# Altium schematic sheet MainBoard_Peripheral.SchDoc
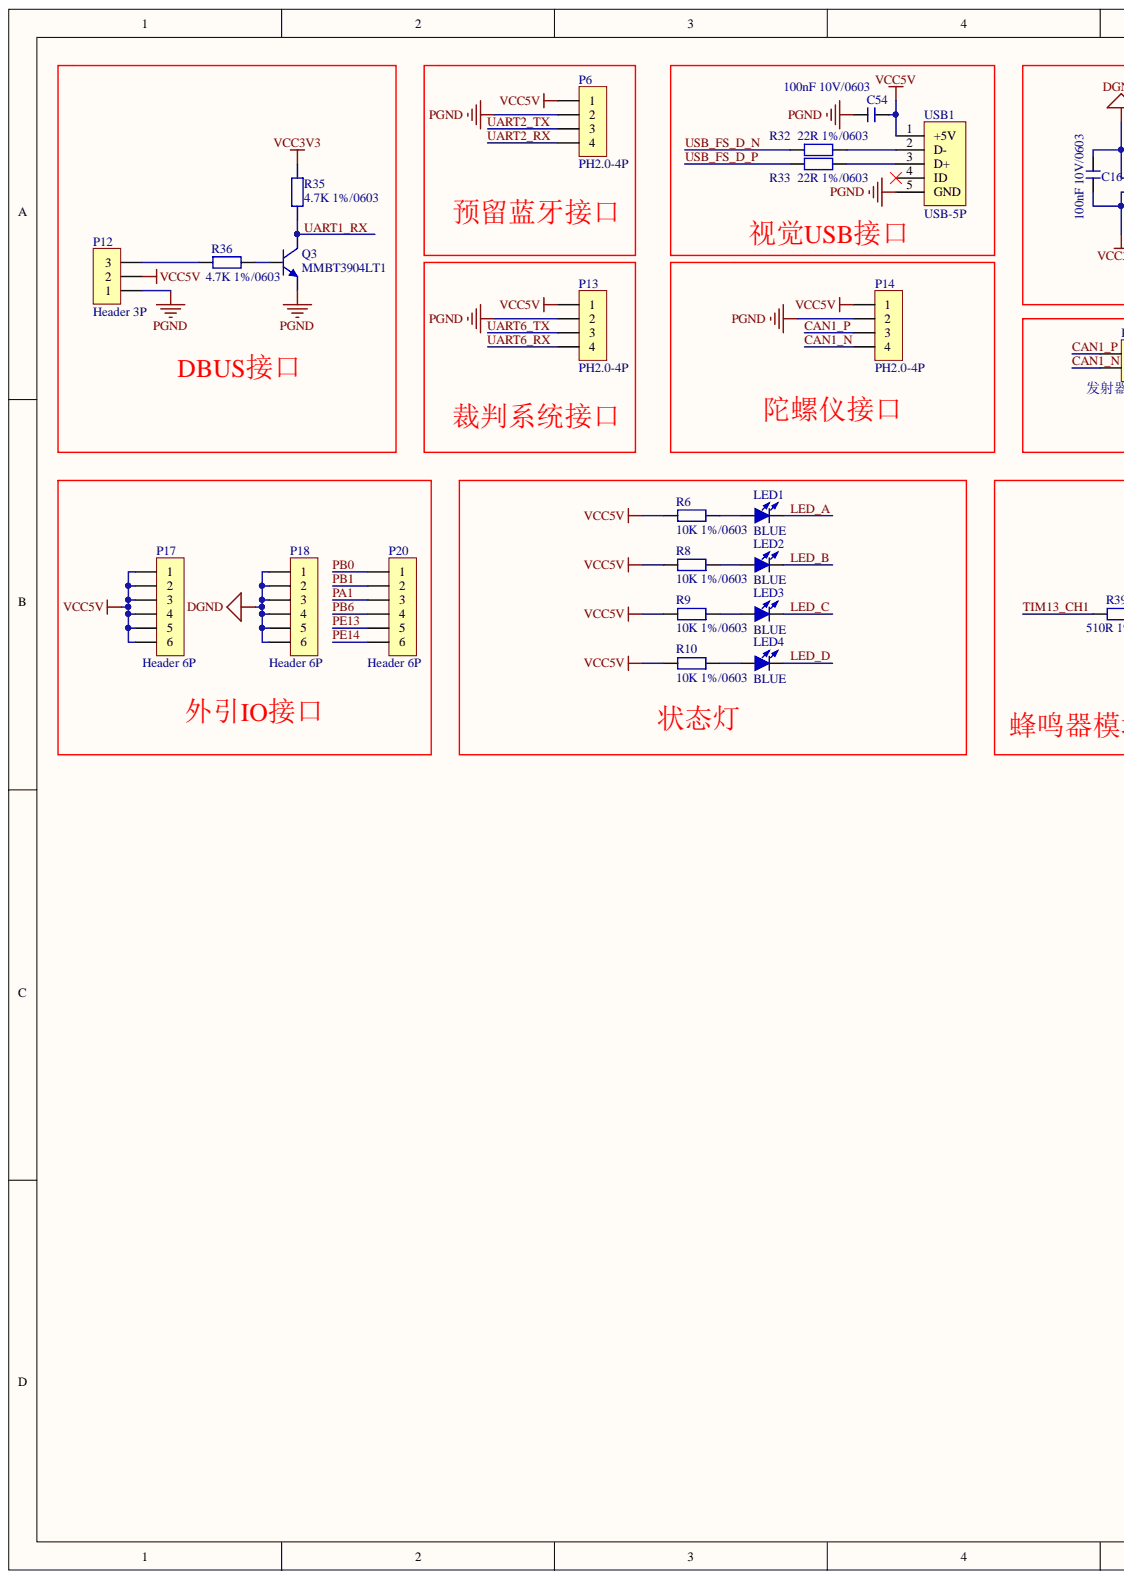
[diagram p0: MainBoard_Peripheral.SchDoc, left]
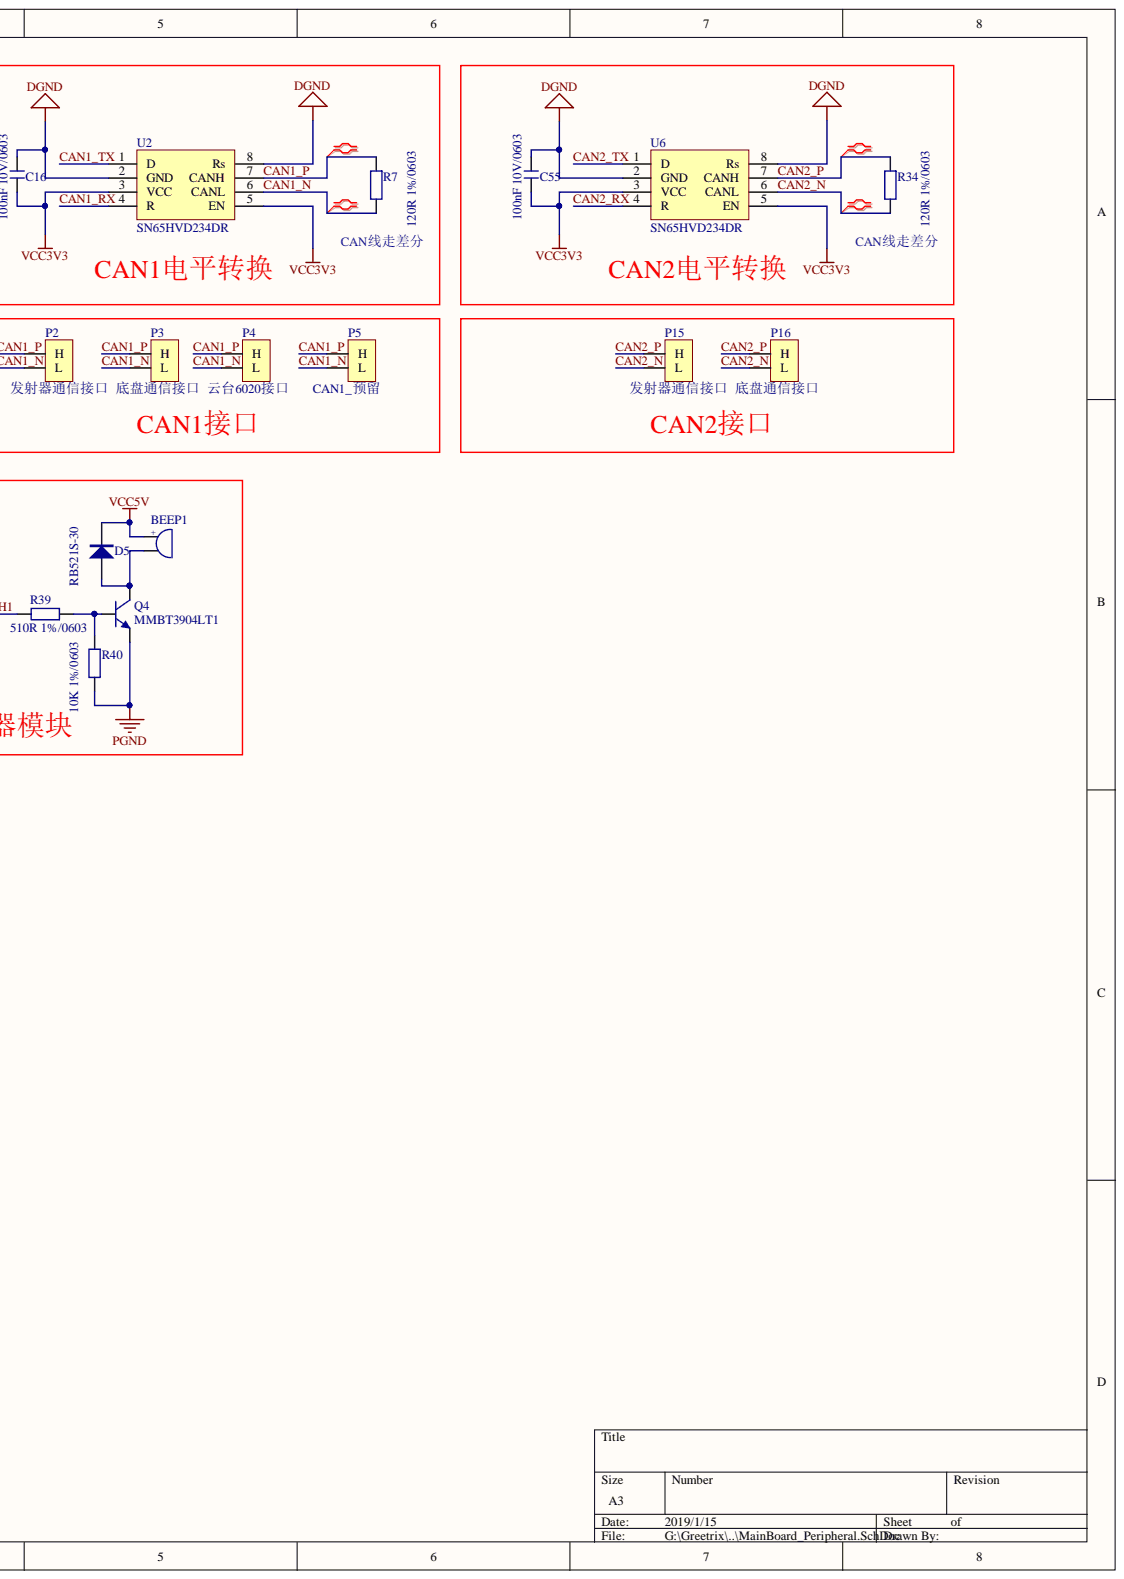
[diagram p1: MainBoard_Peripheral.SchDoc, right]

## Parts
BEEP1  BUZZER  [5020 BUZZER]  (value not drawn)
C16  100nF 10V/0603  [C 0603]
C54  100nF 10V/0603  [C 0603]
C55  100nF 10V/0603  [C 0603]
D5  RB521S-30  [SOD-523]
LED1  BLUE  [LED 0603]
LED2  BLUE  [LED 0603]
LED3  BLUE  [LED 0603]
LED4  BLUE  [LED 0603]
P2  发射器通信接口  [GH1.25_2P]
P3  底盘通信接口  [GH1.25_2P]
P4  云台6020接口  [GH1.25_2P]
P5  CAN1_预留  [GH1.25_2P]
P6  PH2.0-4P  [PH2.0-WS-4P]
P12  Header 3P  [HDR2.54-WI-3P]
P13  PH2.0-4P  [PH2.0-WS-4P]
P14  PH2.0-4P  [PH2.0-WS-4P]
P15  发射器通信接口  [GH1.25_2P]
P16  底盘通信接口  [GH1.25_2P]
P17  Header 6P  [HDR2.54-LI-6P]
P18  Header 6P  [HDR2.54-LI-6P]
P20  Header 6P  [HDR2.54-LI-6P]
Q3  MMBT3904LT1  [SOT23]
Q4  MMBT3904LT1  [SOT23]
R6  10K 1%/0603  [R 0603]
R7  120R 1%/0603  [R 0603]
R8  10K 1%/0603  [R 0603]
R9  10K 1%/0603  [R 0603]
R10  10K 1%/0603  [R 0603]
R32  22R 1%/0603  [R 0603]
R33  22R 1%/0603  [R 0603]
R34  120R 1%/0603  [R 0603]
R35  4.7K 1%/0603  [R 0603]
R36  4.7K 1%/0603  [R 0603]
R39  510R 1%/0603  [R 0603]
R40  10K 1%/0603  [R 0603]
U2  SN65HVD234DR  [SOP8]
U6  SN65HVD234DR  [SOP8]
USB1  USB-5P  [USB-MICRO_D]

## Nets
DGND: C16.2 C55.2 P18.1 P18.2 P18.3 P18.4 P18.5 P18.6 U2.2(GND) U2.8(Rs) U6.2(GND) U6.8(Rs)
PGND: C54.2 P6.2 P12.1 P13.2 P14.2 Q3.2 Q4.2 R40.1 USB1.5(GND)
VCC3V3: C16.1 C55.1 R35.2 U2.3(VCC) U2.5(EN) U6.3(VCC) U6.5(EN)
VCC5V: BEEP1.1 C54.1 D5.2 P6.1 P12.2 P13.1 P14.1 P17.1 P17.2 P17.3 P17.4 P17.5 P17.6 R6.1 R8.1 R9.1 R10.1 USB1.1(+5V)
CAN1_N: P2.2 P3.2 P4.2 P5.2 P14.4 R7.1 U2.6(CANL)
CAN1_P: P2.1 P3.1 P4.1 P5.1 P14.3 R7.2 U2.7(CANH)
CAN1_RX: U2.4(R)
CAN1_TX: U2.1(D)
CAN2_N: P15.2 P16.2 R34.1 U6.6(CANL)
CAN2_P: P15.1 P16.1 R34.2 U6.7(CANH)
CAN2_RX: U6.4(R)
CAN2_TX: U6.1(D)
LED_A: LED1.2
LED_B: LED2.2
LED_C: LED3.2
LED_D: LED4.2
PA1: P20.3
PB0: P20.1
PB1: P20.2
PB6: P20.4
PE13: P20.5
PE14: P20.6
TIM13_CH1: R39.1
UART1_RX: Q3.3 R35.1
UART2_RX: P6.4
UART2_TX: P6.3
UART6_RX: P13.4
UART6_TX: P13.3
USB_FS_D_N: R32.2
USB_FS_D_P: R33.2
NetBEEP1_2: BEEP1.2 D5.1 Q4.3
NetLED1_1: LED1.1 R6.2
NetLED2_1: LED2.1 R8.2
NetLED3_1: LED3.1 R9.2
NetLED4_1: LED4.1 R10.2
NetP12_3: P12.3 R36.2
NetQ3_1: Q3.1 R36.1
NetQ4_1: Q4.1 R39.2 R40.2
NetR32_1: R32.1 USB1.2(D-)
NetR33_1: R33.1 USB1.3(D+)

Not connected: USB1 4(ID)

## Library data of the parts
BEEP1 = BUZZER: 蜂鸣器; Component Kind: Standard; Library Name: SelfLib_GR.SchLib
C16 C54 C55 = C: 无极性贴片电容; Component Kind: Standard; Library Name: SelfLib_GR.SchLib
D5 = RB521S-30: 30V 200mA肖特基二极管 VF=0.5; Component Kind: Standard; Library Name: SelfLib_GR.SchLib
LED1-LED4 = LED: 贴片发光二极管; Component Kind: Standard; Library Name: SelfLib_GR.SchLib
P2-P5 P15 P16 = CAN 2P_1: Component Kind: Standard; Library Name: SelfLib_GR.SchLib
P6 P13 P14 = Header 4P: 4P端子; Component Kind: Standard; Library Name: SelfLib_GR.SchLib
P12 = Header 3P: 3P端子; Component Kind: Standard; Library Name: SelfLib_GR.SchLib
P17 P18 P20 = Header 6P: 6P端子; Component Kind: Standard; Library Name: SelfLib_GR.SchLib
Q3 Q4 = MMBT3904LT1: 贴片三极管 40V 200mA; Component Kind: Standard; Library Name: SelfLib_GR.SchLib
R6-R10 R32-R36 R39 R40 = R: 贴片电阻; Component Kind: Standard; Library Name: SelfLib_GR.SchLib
U2 U6 = SN65HVD234DR: 3.3V CAN转换芯片; Component Kind: Standard; Library Name: SelfLib_GR.SchLib
USB1 = USB-5P: 微型USB母座; Component Kind: Standard; Library Name: SelfLib_GR.SchLib
